# Altium schematic sheet Extras.SchDoc(Extras)
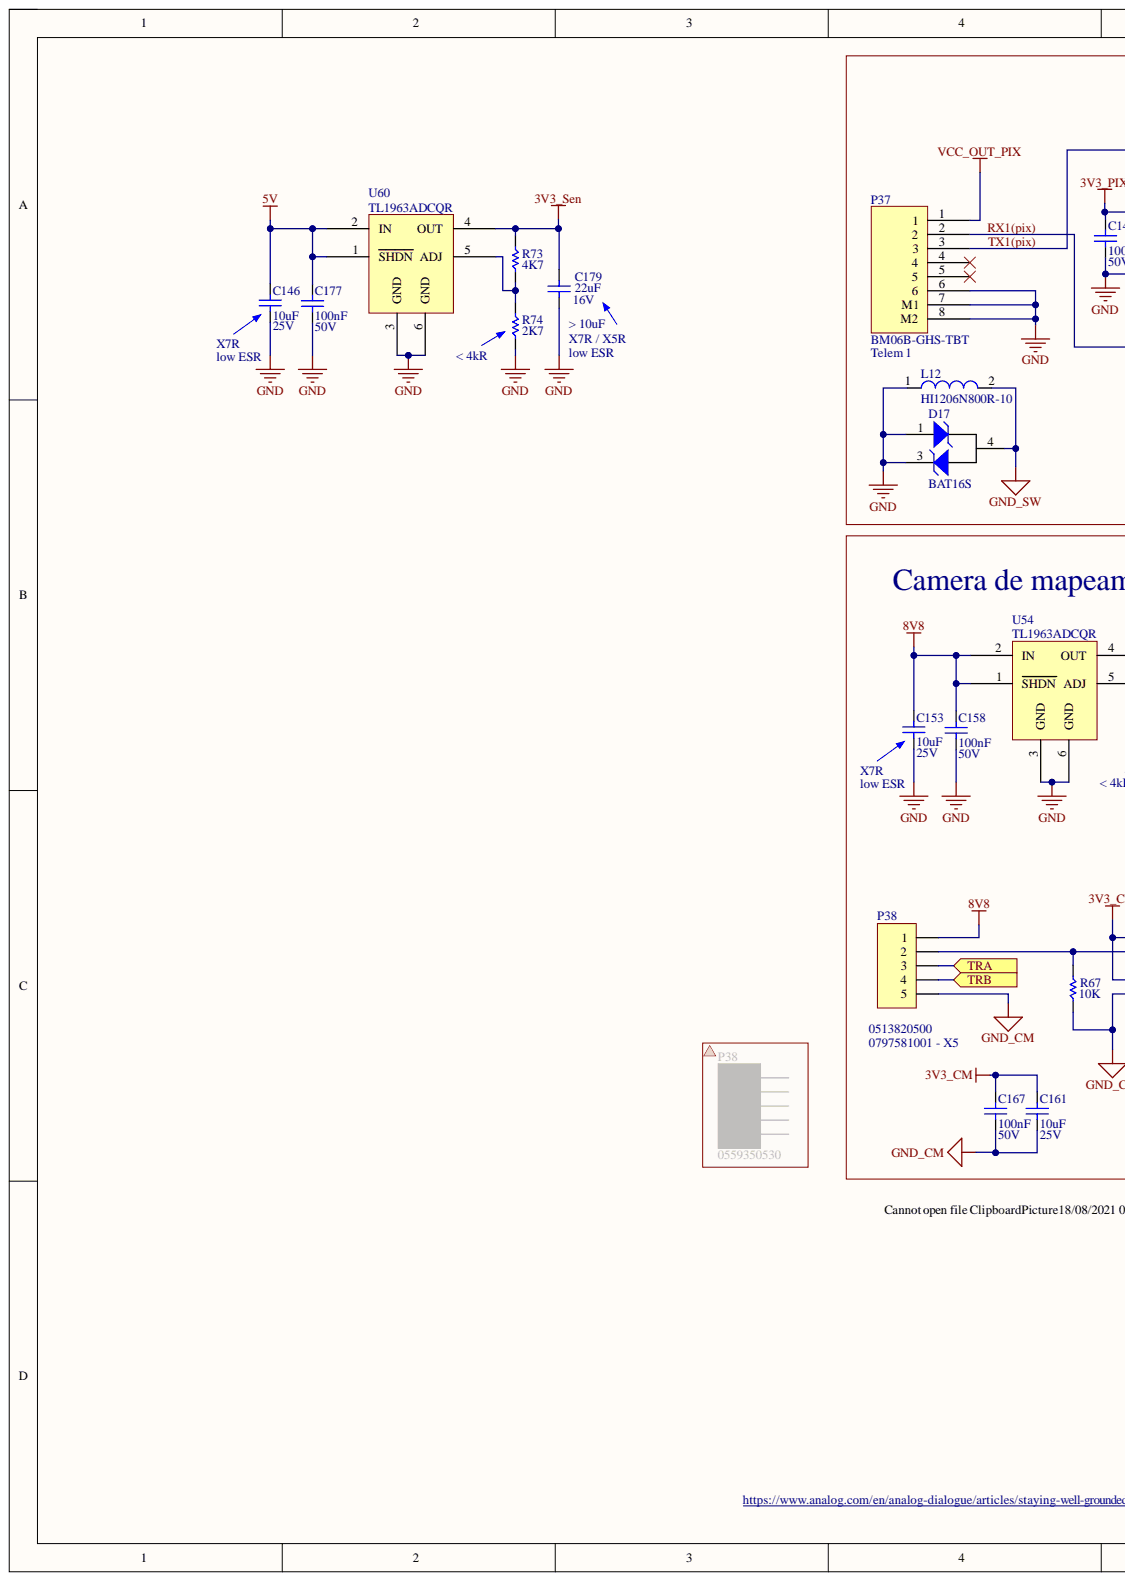
[diagram p0: Extras.SchDoc, left]
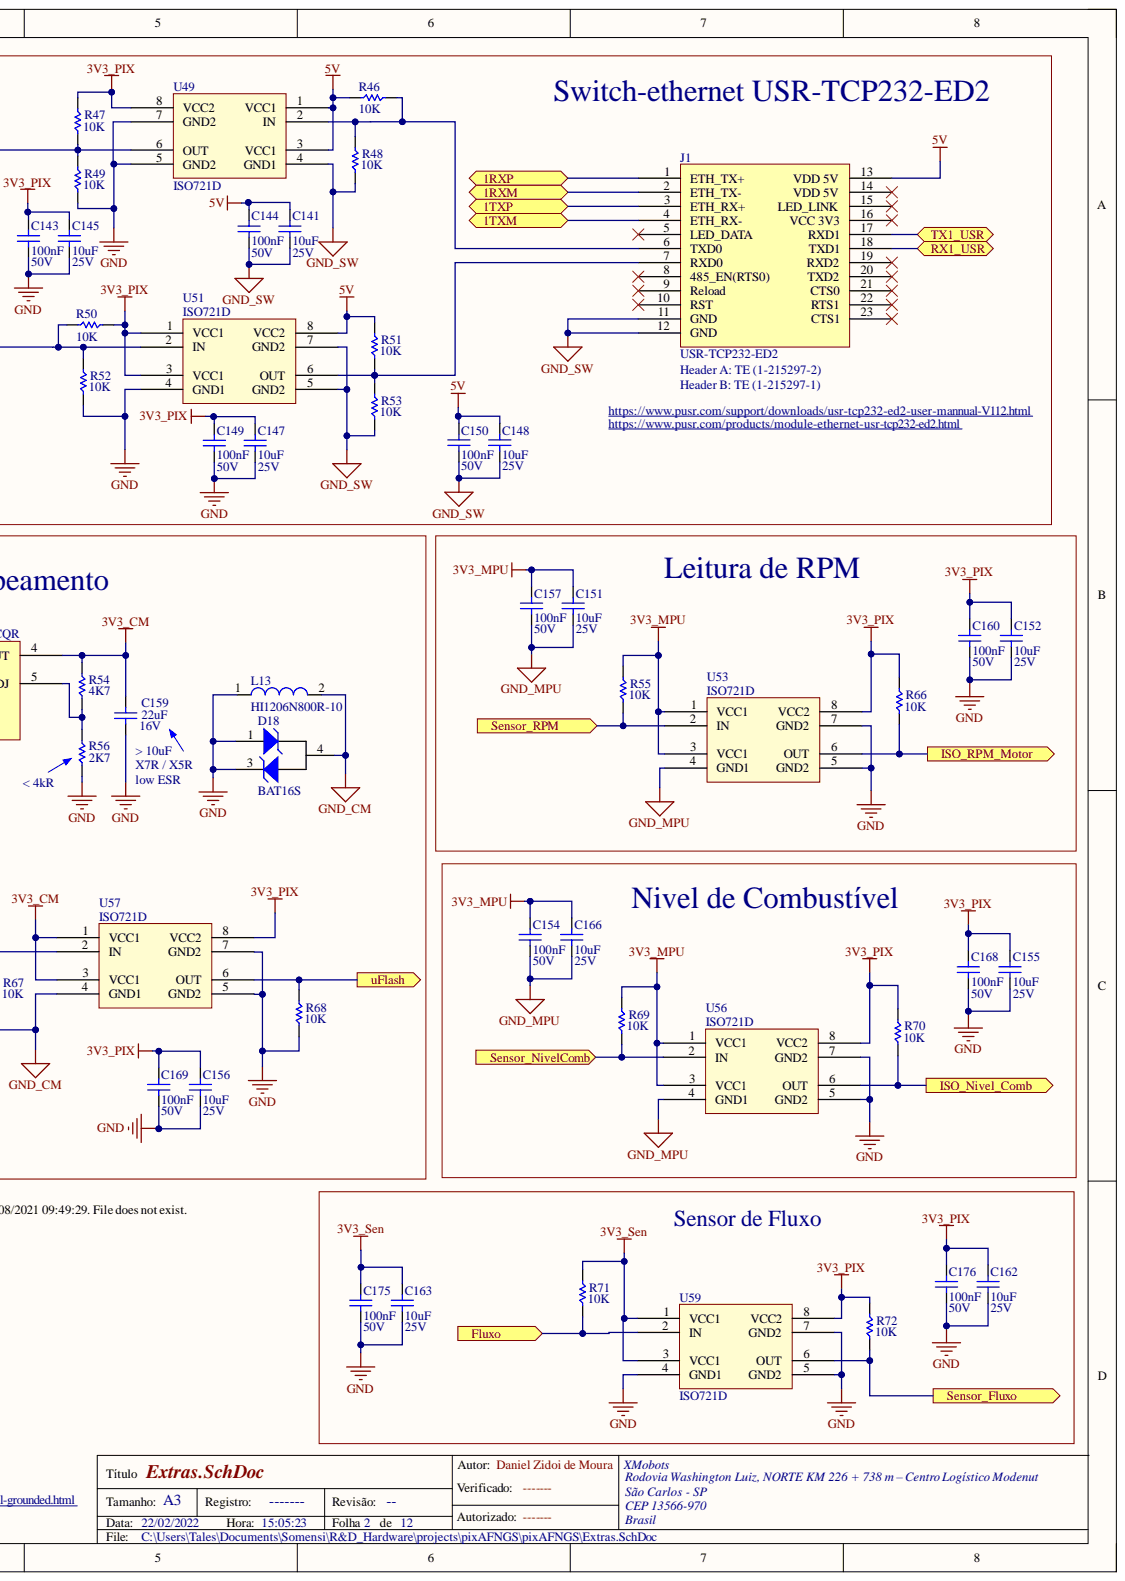
[diagram p1: Extras.SchDoc, right]

## Parts
C141  Capacitance: 10uF  Voltage: 25V  [0603]  (hidden comment: GRM188R61E106KA73J)
C143  Capacitance: 100nF  Voltage: 50V  [0603]  (hidden comment: CL10B104MB8NNNC)
C144  Capacitance: 100nF  Voltage: 50V  [CAP-XMO-0603]  (hidden comment: CL10B104MB8NNNC)
C145  Capacitance: 10uF  Voltage: 25V  [CAP-XMO-0603]  (hidden comment: GRM188R61E106KA73J)
C146  Capacitance: 10uF  Voltage: 25V  [CAP-XMO-0603]  (hidden comment: GRM188R61E106KA73J)
C147  Capacitance: 10uF  Voltage: 25V  [CAP-XMO-0603]  (hidden comment: GRM188R61E106KA73J)
C148  Capacitance: 10uF  Voltage: 25V  [CAP-XMO-0603]  (hidden comment: GRM188R61E106KA73J)
C149  Capacitance: 100nF  Voltage: 50V  [0603]  (hidden comment: CL10B104MB8NNNC)
C150  Capacitance: 100nF  Voltage: 50V  [0603]  (hidden comment: CL10B104MB8NNNC)
C151  Capacitance: 10uF  Voltage: 25V  [CAP-XMO-0603]  (hidden comment: GRM188R61E106KA73J)
C152  Capacitance: 10uF  Voltage: 25V  [CAP-XMO-0603]  (hidden comment: GRM188R61E106KA73J)
C153  Capacitance: 10uF  Voltage: 25V  [CAP-XMO-0603]  (hidden comment: GRM188R61E106KA73J)
C154  Capacitance: 100nF  Voltage: 50V  [CAP-XMO-0603]  (hidden comment: CL10B104MB8NNNC)
C155  Capacitance: 10uF  Voltage: 25V  [CAP-XMO-0603]  (hidden comment: GRM188R61E106KA73J)
C156  Capacitance: 10uF  Voltage: 25V  [0603]  (hidden comment: GRM188R61E106KA73J)
C157  Capacitance: 100nF  Voltage: 50V  [0603]  (hidden comment: CL10B104MB8NNNC)
C158  Capacitance: 100nF  Voltage: 50V  [0603]  (hidden comment: CL10B104MB8NNNC)
C159  Capacitance: 22uF  Voltage: 16V  [SMD-CER-1206]  (hidden comment: CL31A226KOCLNNC)
C160  Capacitance: 100nF  Voltage: 50V  [0603]  (hidden comment: CL10B104MB8NNNC)
C161  Capacitance: 10uF  Voltage: 25V  [CAP-XMO-0603]  (hidden comment: GRM188R61E106KA73J)
C162  Capacitance: 10uF  Voltage: 25V  [CAP-XMO-0603]  (hidden comment: GRM188R61E106KA73J)
C163  Capacitance: 10uF  Voltage: 25V  [CAP-XMO-0603]  (hidden comment: GRM188R61E106KA73J)
C166  Capacitance: 10uF  Voltage: 25V  [0603]  (hidden comment: GRM188R61E106KA73J)
C167  Capacitance: 100nF  Voltage: 50V  [0603]  (hidden comment: CL10B104MB8NNNC)
C168  Capacitance: 100nF  Voltage: 50V  [0603]  (hidden comment: CL10B104MB8NNNC)
C169  Capacitance: 100nF  Voltage: 50V  [0603]  (hidden comment: CL10B104MB8NNNC)
C175  Capacitance: 100nF  Voltage: 50V  [0603]  (hidden comment: CL10B104MB8NNNC)
C176  Capacitance: 100nF  Voltage: 50V  [0603]  (hidden comment: CL10B104MB8NNNC)
C177  Capacitance: 100nF  Voltage: 50V  [0603]  (hidden comment: CL10B104MB8NNNC)
C179  Capacitance: 22uF  Voltage: 16V  [SMD-CER-1206]  (hidden comment: CL31A226KOCLNNC)
D17  BAT16S  [SOT223-4]
D18  BAT16S  [SOT223-4]
J1  USR-TCP232-ED2  [USR-TCP232-ED2]
L12  HI1206N800R-10  [1206]
L13  HI1206N800R-10  [1206]
P37  BM06B-GHS-TBT  [BM06B-GHS-TBT]
P38  J-CON-5VIAS-TH  [J-CON-5VIAS-TH]  (value not drawn)
R46  Resistance: 10K  [RES-XMO-0603]  (hidden comment: RMCF0603FT10K0)
R47  Resistance: 10K  [RES-XMO-0603]  (hidden comment: RMCF0603FT10K0)
R48  Resistance: 10K  [RES-XMO-0603]  (hidden comment: RMCF0603FT10K0)
R49  Resistance: 10K  [RES-XMO-0603]  (hidden comment: RMCF0603FT10K0)
R50  Resistance: 10K  [RES-XMO-0603]  (hidden comment: RMCF0603FT10K0)
R51  Resistance: 10K  [RES-XMO-0603]  (hidden comment: RMCF0603FT10K0)
R52  Resistance: 10K  [RES-XMO-0603]  (hidden comment: RMCF0603FT10K0)
R53  Resistance: 10K  [RES-XMO-0603]  (hidden comment: RMCF0603FT10K0)
R54  Resistance: 4K7  [0603]  (hidden comment: RMCF0603FT4K70)
R55  Resistance: 10K  [RES-XMO-0603]  (hidden comment: RMCF0603FT10K0)
R56  Resistance: 2K7  [0603]  (hidden comment: CRGCQ0603F2K7)
R66  Resistance: 10K  [RES-XMO-0603]  (hidden comment: RMCF0603FT10K0)
R67  Resistance: 10K  [RES-XMO-0603]  (hidden comment: RMCF0603FT10K0)
R68  Resistance: 10K  [RES-XMO-0603]  (hidden comment: RMCF0603FT10K0)
R69  Resistance: 10K  [RES-XMO-0603]  (hidden comment: RMCF0603FT10K0)
R70  Resistance: 10K  [RES-XMO-0603]  (hidden comment: RMCF0603FT10K0)
R71  Resistance: 10K  [RES-XMO-0603]  (hidden comment: RMCF0603FT10K0)
R72  Resistance: 10K  [RES-XMO-0603]  (hidden comment: RMCF0603FT10K0)
R73  Resistance: 4K7  [0603]  (hidden comment: RMCF0603FT4K70)
R74  Resistance: 2K7  [0603]  (hidden comment: CRGCQ0603F2K7)
U49  ISO721D  [SOIC-8_D-PDSO-G8]
U51  ISO721D  [SOIC-8_D-PDSO-G8]
U53  ISO721D  [SOIC-8_D-PDSO-G8]
U54  TL1963ADCQR  [SOT127_R-PDSO-G6]
U56  ISO721D  [SOIC-8_D-PDSO-G8]
U57  ISO721D  [SOIC-8_D-PDSO-G8]
U59  ISO721D  [SOIC-8_D-PDSO-G8]
U60  TL1963ADCQR  [SOT127_R-PDSO-G6]

## Ports
1RXM, 1RXP, 1TXM, 1TXP, Fluxo, ISO_Nivel_Comb, ISO_RPM_Motor, RX1_USR, Sensor_Fluxo, Sensor_NivelComb, Sensor_RPM, TRA, TRB, TX1_USR, uFlash

## Nets
3V3_CM: C159.2 C161.2 C167.2 R54.2 U54.4(OUT) U57.1(VCC1) U57.3(VCC1)
3V3_MPU: C151.2 C154.2 C157.2 C166.2 R55.1 R69.1 U53.1(VCC1) U53.3(VCC1) U56.1(VCC1) U56.3(VCC1)
3V3_PIX: C143.2 C145.2 C147.2 C149.2 C152.2 C155.2 C156.2 C160.2 C162.2 C168.2 C169.2 C176.2 R47.1 R50.2 R66.1 R70.1 R72.1 U49.8(VCC2) U51.1(VCC1) U51.3(VCC1) U53.8(VCC2) U56.8(VCC2) U57.8(VCC2) U59.8(VCC2)
3V3_Sen: C163.2 C175.2 C179.2 R71.1 R73.2 U59.1(VCC1) U59.3(VCC1) U60.4(OUT)
5V: C141.2 C144.2 C146.2 C148.2 C150.2 C177.2 J1.13(VDD_5V) R46.1 R51.1 U49.1(VCC1) U49.3(VCC1) U51.8(VCC2) U60.1(SHDN) U60.2(IN)
8V8: C153.2 C158.2 P38.1 U54.1(SHDN) U54.2(IN)
GND: C143.1 C145.1 C146.1 C147.1 C149.1 C152.1 C153.1 C155.1 C156.1 C158.1 C159.1 C160.1 C162.1 C163.1 C168.1 C169.1 C175.1 C176.1 C177.1 C179.1 D17.1 D17.3 D18.1 D18.3 L12.1 L13.1 P37.6 P37.7(M1) P37.8(M2) R49.2 R52.2 R56.1 R68.2 R74.1 U49.5(GND2) U49.7(GND2) U51.4(GND1) U53.5(GND2) U53.7(GND2) U54.3(GND) U54.6(GND) U56.5(GND2) U56.7(GND2) U57.5(GND2) U57.7(GND2) U59.4(GND1) U59.5(GND2) U59.7(GND2) U60.3(GND) U60.6(GND)
GND_CM: C161.1 C167.1 D18.4 L13.2 P38.5 R67.2 U57.4(GND1)
GND_MPU: C151.1 C154.1 C157.1 C166.1 U53.4(GND1) U56.4(GND1)
GND_SW: C141.1 C144.1 C148.1 C150.1 D17.4 J1.11(GND) J1.12(GND) L12.2 R48.2 R53.2 U49.4(GND1) U51.5(GND2) U51.7(GND2)
VCC_OUT_PIX: P37.1
1RXM: J1.2(ETH_TX-) ; port 1RXM
1RXP: J1.1(ETH_TX+) ; port 1RXP
1TXM: J1.4(ETH_RX-) ; port 1TXM
1TXP: J1.3(ETH_RX+) ; port 1TXP
Fluxo: R71.2 U59.2(IN) ; port Fluxo
ISO_Nivel_Comb: R70.2 U56.6(OUT) ; port ISO_Nivel_Comb
ISO_RPM_Motor: R66.2 U53.6(OUT) ; port ISO_RPM_Motor
RX1(pix): P37.2 R50.1 R52.1 U51.2(IN)
RX1_USR: J1.18(TXD1) ; port RX1_USR
Sensor_Fluxo: R72.2 U59.6(OUT) ; port Sensor_Fluxo
Sensor_NivelComb: R69.2 U56.2(IN) ; port Sensor_NivelComb
Sensor_RPM: R55.2 U53.2(IN) ; port Sensor_RPM
TRA: P38.3 ; port TRA
TRB: P38.4 ; port TRB
TX1(pix): P37.3 R47.2 R49.1 U49.6(OUT)
TX1_USR: J1.17(RXD1) ; port TX1_USR
uFlash: R68.1 U57.6(OUT) ; port uFlash
NetJ1_6: J1.6(TXD0) R46.2 R48.1 U49.2(IN)
NetJ1_7: J1.7(RXD0) R51.2 R53.1 U51.6(OUT)
NetP38_2: P38.2 R67.1 U57.2(IN)
NetR54_1: R54.1 R56.2 U54.5(ADJ)
NetR73_1: R73.1 R74.2 U60.5(ADJ)

Not connected: D17 2; D18 2; J1 5(LED_DATA) 8(485_EN(RTS0)) 9(Reload) 10(RST) 14(VDD_5V) 15(LED_LINK) 16(VCC_3V3) 19(RXD2) 20(TXD2) 21(CTS0) 22(RTS1) 23(CTS1); P37 4 5

## Library data of the parts
C141 C145-C148 C151-C153 C155 C156 C161-C163 C166 = C-CER-10uF-25V-X5R-0603: Capacitor 10uF 25V X5R 0603; Component Kind: Standard; Part Number: GRM188R61E106KA73J; Library Name: xmobots_-_comp_library.IntLib
C143 C144 C149 C150 C154 C157 C158 C160 C167-C169 C175-C177 = C-100nF-50V-X7R-0603: Capacitor 100nF 50V X7R 0603; Component Kind: Standard; Part Number: CL10B104MB8NNNC; Library Name: xmobots_-_comp_library.IntLib
C159 C179 = C-22uF-16V-X5R-1206: Capacitor 22uF 16V X5R 1206; Component Kind: Standard; Part Number: CL31A226KOCLNNC; Library Name: xmobots_-_comp_library.IntLib
D17 D18 = BAT16S: DIODE ARRAY SCHOTTKY 60V SOT223; Component Kind: Standard; Library Name: XM_Diodes.SchLib
J1 = USR-TCP232-ED2: Triple Serial TTL UART to Ethernet TC /IP Module; Component Kind: Standard; Library Name: XM_Connector.SchLib
L12 L13 = BLM18SG221TN1D: FERRITE CHIP 220 OHM 2500MA 0603; Component Kind: Standard; Supplier 1: Digi-Key; Supplier Part Number 1: 490-5225-1-ND; Library Name: XM_Inductor.SchLib
P37 = BM06B-GHS-TBT: JST GH 6 Vias Vertical; Component Kind: Standard; Library Name: XM_Connector.SchLib
R46-R53 R55 R66-R72 = R-10K-1/10W-1%-0603: Resistor 10k ohms 1/10W 1% 0603; Component Kind: Standard; Part Number: RMCF0603FT10K0; Power: 1/10W; Tolerance: ±1%; Library Name: xmobots_-_comp_library.IntLib
R54 R73 = R-4K7-1/10W-1%-0603: Resistor 4k7 ohms 1/10W 1% 0603; Component Kind: Standard; Part Number: RMCF0603FT4K70; Power: 1/10W; Tolerance: ±1%; Library Name: xmobots_-_comp_library.IntLib
R56 R74 = R-2K7-1/10W-1%-0603: Resistor 2k7 ohms 1/10W 1% 0603; Component Kind: Standard; Part Number: CRGCQ0603F2K7; Power: 1/10W; Tolerance: ±1%; Library Name: xmobots_-_comp_library.IntLib
U49 U51 U53 U56 U57 U59 = ISO721D: 3.3-V / 5-V HIGH-SPEED DIGITAL ISOLATORS; Component Kind: Standard; Supplier 1: Digi-Key; Supplier Part Number 1: 296-19382-ND; Library Name: XM_IC.SchLib
U54 U60 = TL1963ADCQR: 1.5-A LOW-NOISE FAST-TRANSIENT-RESPONSE LOW-DROPOUT REGULATOR; Component Kind: Standard; Supplier 1: Digi-Key; Supplier Part Number 1: 296-25119-1-ND; Library Name: XM_IC.SchLib
P38 = J-CON-5VIAS-TH: Component Kind: Standard; Library Name: WarLib.IntLib
